# Altium schematic sheet 06)Telemetry.SchDoc(06)Telemetry)
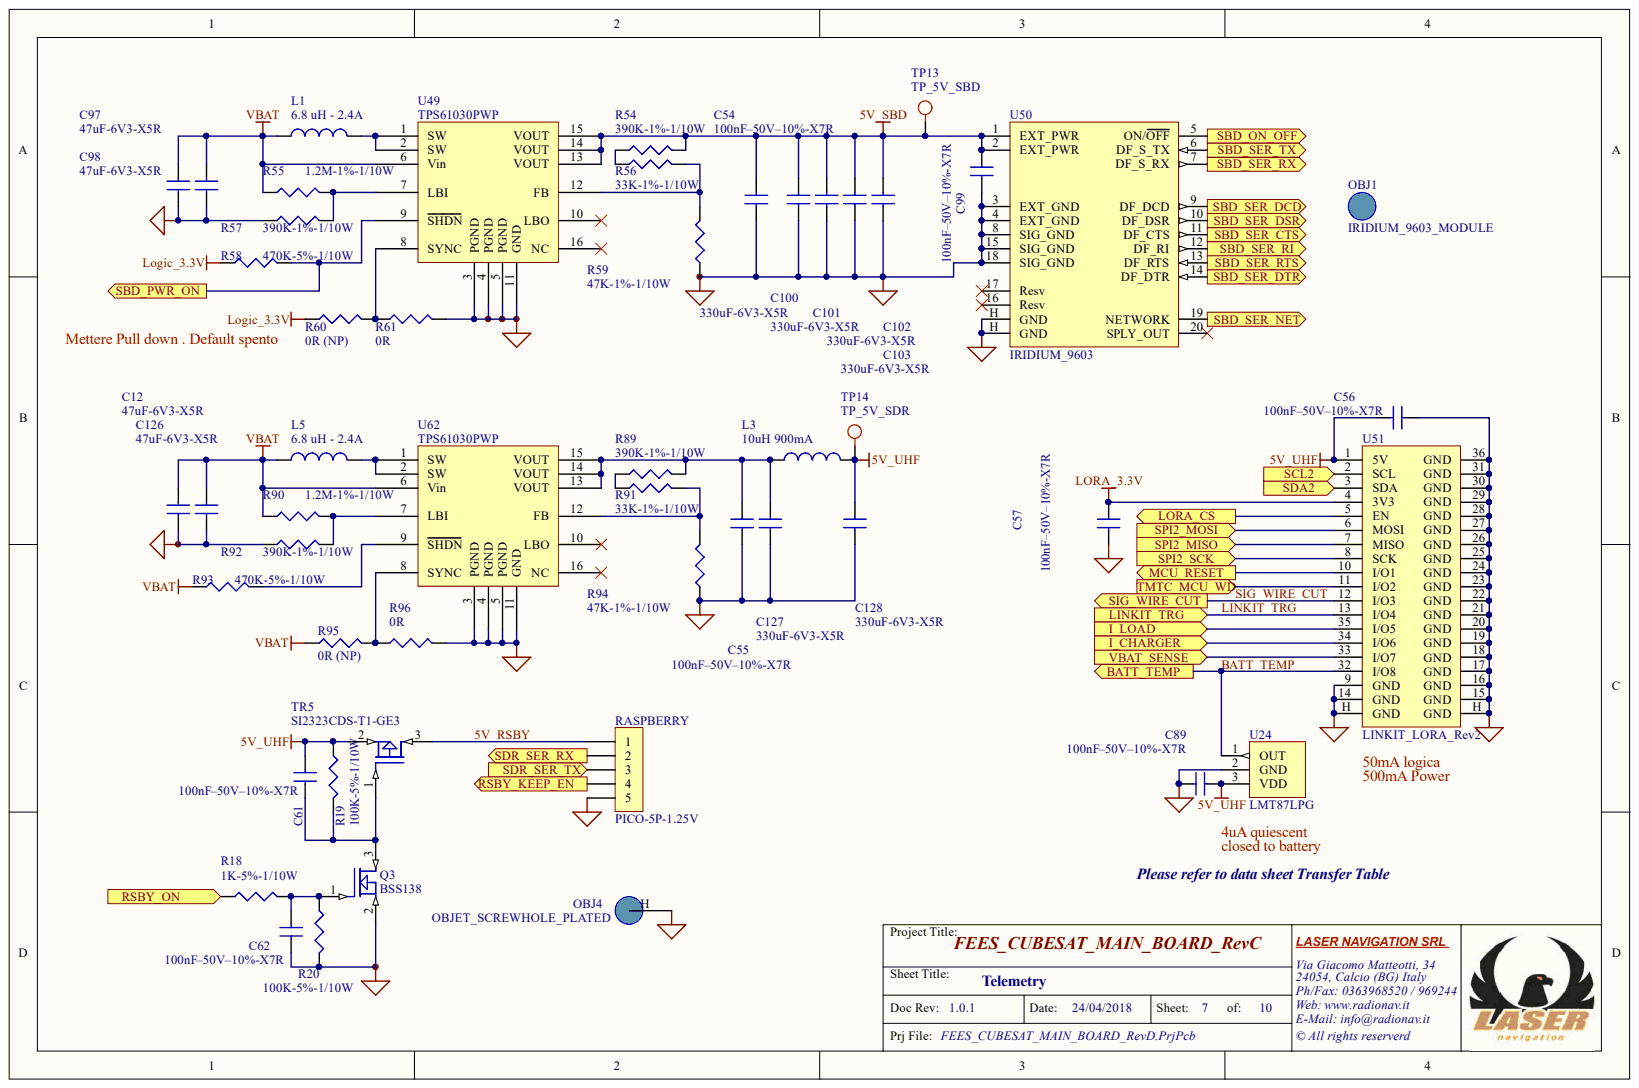
[diagram p0: 06)Telemetry.SchDoc]

## Parts
C12  47uF-6V3-X5R  [0805_CAP]
C54  100nF–50V–10%-X7R  [0603_CAP]
C55  100nF–50V–10%-X7R  [0603_CAP]
C56  100nF–50V–10%-X7R  [0603_CAP]
C57  100nF–50V–10%-X7R  [0603_CAP]
C61  100nF–50V–10%-X7R  [0603_CAP]
C62  100nF–50V–10%-X7R  [0603_CAP]
C89  100nF–50V–10%-X7R  [0603_CAP]
C97  47uF-6V3-X5R  [0805_CAP]
C98  47uF-6V3-X5R  [0805_CAP]
C99  100nF–50V–10%-X7R  [0603_CAP]
C100  330uF-6V3-X5R  [1210_CAP]
C101  330uF-6V3-X5R  [1210_CAP]
C102  330uF-6V3-X5R  [1210_CAP]
C103  330uF-6V3-X5R  [1210_CAP]
C126  47uF-6V3-X5R  [0805_CAP]
C127  330uF-6V3-X5R  [1210_CAP]
C128  330uF-6V3-X5R  [1210_CAP]
L1  6.8 uH - 2.4A  [IND-SMT-IHLP_2020BZ_01]
L3  10uH 900mA  [1210_IND]
L5  6.8 uH - 2.4A  [IND-SMT-IHLP_2020BZ_01]
OBJ1  IRIDIUM_9603_MODULE  [IRIDIUM_9603_OBJ]
OBJ4  OBJET_SCREWHOLE_PLATED  [SCREW2.5MM]
Q3  BSS138  [SOT23]
R18  1K-5%-1/10W  [0603_RES]
R19  100K-5%-1/10W  [0603_RES]
R20  100K-5%-1/10W  [0603_RES]
R54  390K-1%-1/10W  [0603_RES]
R55  1.2M-1%-1/10W  [0603_RES]
R56  33K-1%-1/10W  [0603_RES]
R57  390K-1%-1/10W  [0603_RES]
R58  470K-5%-1/10W  [0603_RES]
R59  47K-1%-1/10W  [0603_RES]
R60  0R (NP)  [0603_RES]
R61  0R  [0603_RES]
R89  390K-1%-1/10W  [0603_RES]
R90  1.2M-1%-1/10W  [0603_RES]
R91  33K-1%-1/10W  [0603_RES]
R92  390K-1%-1/10W  [0603_RES]
R93  470K-5%-1/10W  [0603_RES]
R94  47K-1%-1/10W  [0603_RES]
R95  0R (NP)  [0603_RES]
R96  0R  [0603_RES]
RASPBERRY  PICO-5P-1.25V  [PICO-5P-1.25V]
TP13  TP_5V_SBD  [TP_SMD_PAD_1_5MM]
TP14  TP_5V_SDR  [TP_SMD_PAD_1_5MM]
TR5  SI2323CDS-T1-GE3  [SOT23]
U24  LMT87LPG  [TO92S]
U49  TPS61030PWP  [PDSO16]
U50  IRIDIUM_9603  [IRIDIUM_9603_PCB]
U51  LINKIT_LORA_Rev2  [LINKIT_LORA_Rev2]
U62  TPS61030PWP  [PDSO16]

## Ports
BATT_TEMP, I_CHARGER, I_LOAD, LINKIT_TRG, LORA_CS, MCU_RESET, RSBY_KEEP_EN, RSBY_ON, SBD_ON_OFF, SBD_PWR_ON, SBD_SER_CTS, SBD_SER_DCD, SBD_SER_DSR, SBD_SER_DTR, SBD_SER_NET, SBD_SER_RI, SBD_SER_RTS, SBD_SER_RX, SBD_SER_TX, SCL2, SDA2, SDR_SER_RX, SDR_SER_TX, SIG_WIRE_CUT, SPI2_MISO, SPI2_MOSI, SPI2_SCK, TMTC_MCU_WD, VBAT_SENSE

## Nets
5V_SBD: C54.2 C99.1 C100.1 C101.1 C102.1 C103.1 R54.2 TP13.1 U49.13(VOUT) U49.14(VOUT) U49.15(VOUT) U50.1(EXT_PWR) U50.2(EXT_PWR)
5V_UHF: C56.2 C61.1 C89.2 C128.1 L3.2 R19.1 TP14.1 TR5.2 U24.3 U51.1(5V)
GND: C12.2 C54.1 C55.1 C56.1 C57.1 C62.2 C89.1 C97.2 C98.2 C99.2 C100.2 C101.2 C102.2 C103.2 C126.2 C127.2 C128.2 OBJ4.H Q3.2 R20.2 R57.1 R59.1 R61.2 R92.1 R94.1 R96.2 RASPBERRY.5 U24.2 U49.3(PGND) U49.4(PGND) U49.5(PGND) U49.11(GND) U50.3(EXT_GND) U50.4(EXT_GND) U50.8(SIG_GND) U50.15(SIG_GND) U50.18(SIG_GND) U50.H(GND) U51.9(GND) U51.14(GND) U51.15(GND) U51.16(GND) U51.17(GND) U51.18(GND) U51.19(GND) U51.20(GND) U51.21(GND) U51.22(GND) U51.23(GND) U51.24(GND) U51.25(GND) U51.26(GND) U51.27(GND) U51.28(GND) U51.29(GND) U51.30(GND) U51.31(GND) U51.36(GND) U51.H(GND) U62.3(PGND) U62.4(PGND) U62.5(PGND) U62.11(GND)
LOGIC_3.3V: R58.1 R60.1
LORA_3.3V: C57.2 U51.4(3V3)
VBAT: C12.1 C97.1 C98.1 C126.1 L1.1 L5.1 R55.1 R90.1 R93.1 R95.1 U49.6(Vin) U62.6(Vin)
5V_RSBY: RASPBERRY.1 TR5.3
BATT_TEMP: U24.1 U51.32(I/O8) ; port BATT_TEMP
I_CHARGER: U51.34(I/O6) ; port I_CHARGER
I_LOAD: U51.35(I/O5) ; port I_LOAD
LINKIT_TRG: U51.13(I/O4) ; port LINKIT_TRG
LORA_CS: U51.5(EN) ; port LORA_CS
MCU_RESET: U51.10(I/O1) ; port MCU_RESET
RSBY_KEEP_EN: RASPBERRY.4 ; port RSBY_KEEP_EN
RSBY_ON: R18.1 ; port RSBY_ON
SBD_ON_OFF: U50.5(ON/OFF) ; port SBD_ON_OFF
SBD_PWR_ON: R58.2 U49.9(SHDN) ; port SBD_PWR_ON
SBD_SER_CTS: U50.11(DF_CTS) ; port SBD_SER_CTS
SBD_SER_DCD: U50.9(DF_DCD) ; port SBD_SER_DCD
SBD_SER_DSR: U50.10(DF_DSR) ; port SBD_SER_DSR
SBD_SER_DTR: U50.14(DF_DTR) ; port SBD_SER_DTR
SBD_SER_NET: U50.19(NETWORK) ; port SBD_SER_NET
SBD_SER_RI: U50.12(DF_RI) ; port SBD_SER_RI
SBD_SER_RTS: U50.13(DF_RTS) ; port SBD_SER_RTS
SBD_SER_RX: U50.7(DF_S_RX) ; port SBD_SER_RX
SBD_SER_TX: U50.6(DF_S_TX) ; port SBD_SER_TX
SCL2: U51.2(SCL) ; port SCL2
SDA2: U51.3(SDA) ; port SDA2
SDR_SER_RX: RASPBERRY.2 ; port SDR_SER_RX
SDR_SER_TX: RASPBERRY.3 ; port SDR_SER_TX
SIG_WIRE_CUT: U51.12(I/O3) ; port SIG_WIRE_CUT
SPI2_MISO: U51.7(MISO) ; port SPI2_MISO
SPI2_MOSI: U51.6(MOSI) ; port SPI2_MOSI
SPI2_SCK: U51.8(SCK) ; port SPI2_SCK
TMTC_MCU_WD: U51.11(I/O2) ; port TMTC_MCU_WD
VBAT_SENSE: U51.33(I/O7) ; port VBAT_SENSE
NetC55_2: C55.2 C127.1 L3.1 R89.2 U62.13(VOUT) U62.14(VOUT) U62.15(VOUT)
NetC61_2: C61.2 Q3.3 R19.2 TR5.1
NetC62_1: C62.1 Q3.1 R18.2 R20.1
NetL1_2: L1.2 U49.1(SW) U49.2(SW)
NetL5_2: L5.2 U62.1(SW) U62.2(SW)
NetR54_1: R54.1 R56.1
NetR55_2: R55.2 R57.2 U49.7(LBI)
NetR56_2: R56.2 R59.2 U49.12(FB)
NetR60_2: R60.2 R61.1 U49.8(SYNC)
NetR89_1: R89.1 R91.1
NetR90_2: R90.2 R92.2 U62.7(LBI)
NetR91_2: R91.2 R94.2 U62.12(FB)
NetR93_2: R93.2 U62.9(SHDN)
NetR95_2: R95.2 R96.1 U62.8(SYNC)

Not connected: U49 10(LBO) 16(NC); U50 16(Resv) 17(Resv) 20(SPLY_OUT); U62 10(LBO) 16(NC)

## Library data of the parts
C12 C97 C98 C126 = CAP: Non polarized capacitor; 1.Part Number: JMK212BJ476MG-T; 2.Manufacturer: Taiyo Yuden; 3.Description: CAP CER 47UF 6.3V X5R 0805; 5.Mounting: Y; 4.Supplier: Digikey 587-1779-1-ND; Component Kind: Standard; Library Name: User Sch Library.SchLib
C54-C57 C61 C62 C89 C99 = CAP: Non polarized capacitor; Component Kind: Standard; Library Name: User Sch Library.SchLib
C100-C103 C127 C128 = CAP: Non polarized capacitor; 1.Part Number: JMK325ABJ337MM-P; 2.Manufacturer: Taiyo Yuden; 3.Description: CAP CER 330UF 6.3V X5R 1210; 5.Mounting: Y; 4.Supplier: Digikey 587-5449-1-ND; Component Kind: Standard; Library Name: User Sch Library.SchLib
L1 L5 = INDUCTOR: 1.Part Number: IHLP2020BZER6R8M01; 2.Manufacturer: Vishay Dale; 3.Description: FIXED IND 6.8UH 2.4A 150 MOHM; 5.Mounting: Y; 4.Supplier: digikey 541-1092-1-ND // RS 748-6830; Component Kind: Standard; Description: Inductor; Library Name: User Sch Library.SchLib
L3 = INDUCTOR: 1.Part Number: 1277AS-H-100M; 2.Manufacturer: Murata; 3.Description: FIXED IND 10UH 900MA 420 MOHM; 5.Mounting: Y; 4.Supplier: Digikey 490-10589-6-ND; Component Kind: Standard; Description: Inductor; Library Name: User Sch Library.SchLib
OBJ1 = OBJET: GENERIC OBJECT; 1.Part Number: 9603; 3.Description: SBD Transceiver; 5.Mounting: Y; 2.Manufacturer: IRIDIUM; 4.Supplier: Iridium; Component Kind: Standard; Library Name: User Sch Library.SchLib
OBJ4 = OBJET_SCREWHOLE_PLATED: OBJET SHIELD; Component Kind: Standard; Library Name: User Sch Library.SchLib
Q3 = MOSFET N: 2.Manufacturer: Fairchild/ON Semiconductor; 3.Description: MOSFET N-CH 50V 220MA SOT-23; 5.Mounting: Y; 1.Part Number: BSS138; 4.Supplier: Digikey BSS138CT-ND; Component Kind: Standard; Library Name: User Sch Library.SchLib
R18-R20 R55 R57 R58 R61 R90 R92 R93 = R: Component Kind: Standard; Library Name: User Sch Library.SchLib
R54 R56 R59 R89 R91 R94 R96 = R: 5.Mounting: Y; Component Kind: Standard; Library Name: User Sch Library.SchLib
R60 R95 = R: 5.Mounting: not mounted; Component Kind: Standard; Library Name: User Sch Library.SchLib
RASPBERRY = CON5: Connector; 1.Part Number: 53398-0571; 2.Manufacturer: Molex, LLC; 3.Description: CONN HEADER 5POS 1.25MM VERT SMD; 5.Mounting: Y; 4.Supplier: Digikey WM7609CT-ND; Component Kind: Standard; Library Name: User Sch Library.SchLib
TP13 TP14 = TP: Test Point; Component Kind: Standard; Library Name: User Sch Library.SchLib
TR5 = MOSFET P_1: 2.Manufacturer: Vishay Siliconix; 3.Description: MOSFET P-CH 20V 6A SOT-23; 5.Mounting: Y; 1.Part Number: SI2323CDS-T1-GE3; 4.Supplier: Digikey  SI2323CDS-T1-GE3CT-ND; Component Kind: Standard; Library Name: User Sch Library.SchLib
U24 = LMT87LPG: ANALOG TEMPERATURE SENSOR; 2.Manufacturer: Texas Instruments; 5.Mounting: Y; 1.Part Number: LMT87LPG; 3.Description: ANALOG TEMPERATURE SENSOR; 4.Supplier: Digikey 296-47011-ND; Component Kind: Standard; Library Name: User Sch Library.SchLib
U49 U62 = TPS61030PWP: Step Up; 1.Part Number: TPS61030PWPR; 2.Manufacturer: Texas Instruments; 3.Description: Boost Switching Regulato; 5.Mounting: Y; 4.Supplier: Digikey 296-14416-1-ND; Component Kind: Standard; Library Name: User Sch Library.SchLib
U50 = IRIDIUM_9603: two-way satellite data  transceiver; 1.Part Number: SS4-10-3.00-L-D-K-TR; 2.Manufacturer: Samtec; 3.Description: Ultra fine pich socket 20 pin; 5.Mounting: Y; 4.Supplier: Samtec.com; Component Kind: Standard; Library Name: User Sch Library.SchLib
U51 = LINKIT_LORA_Rev2: RADIO TRANSCEIVER; Component Kind: Standard; Library Name: User Sch Library.SchLib
